# Altium schematic sheet Main.SchDoc
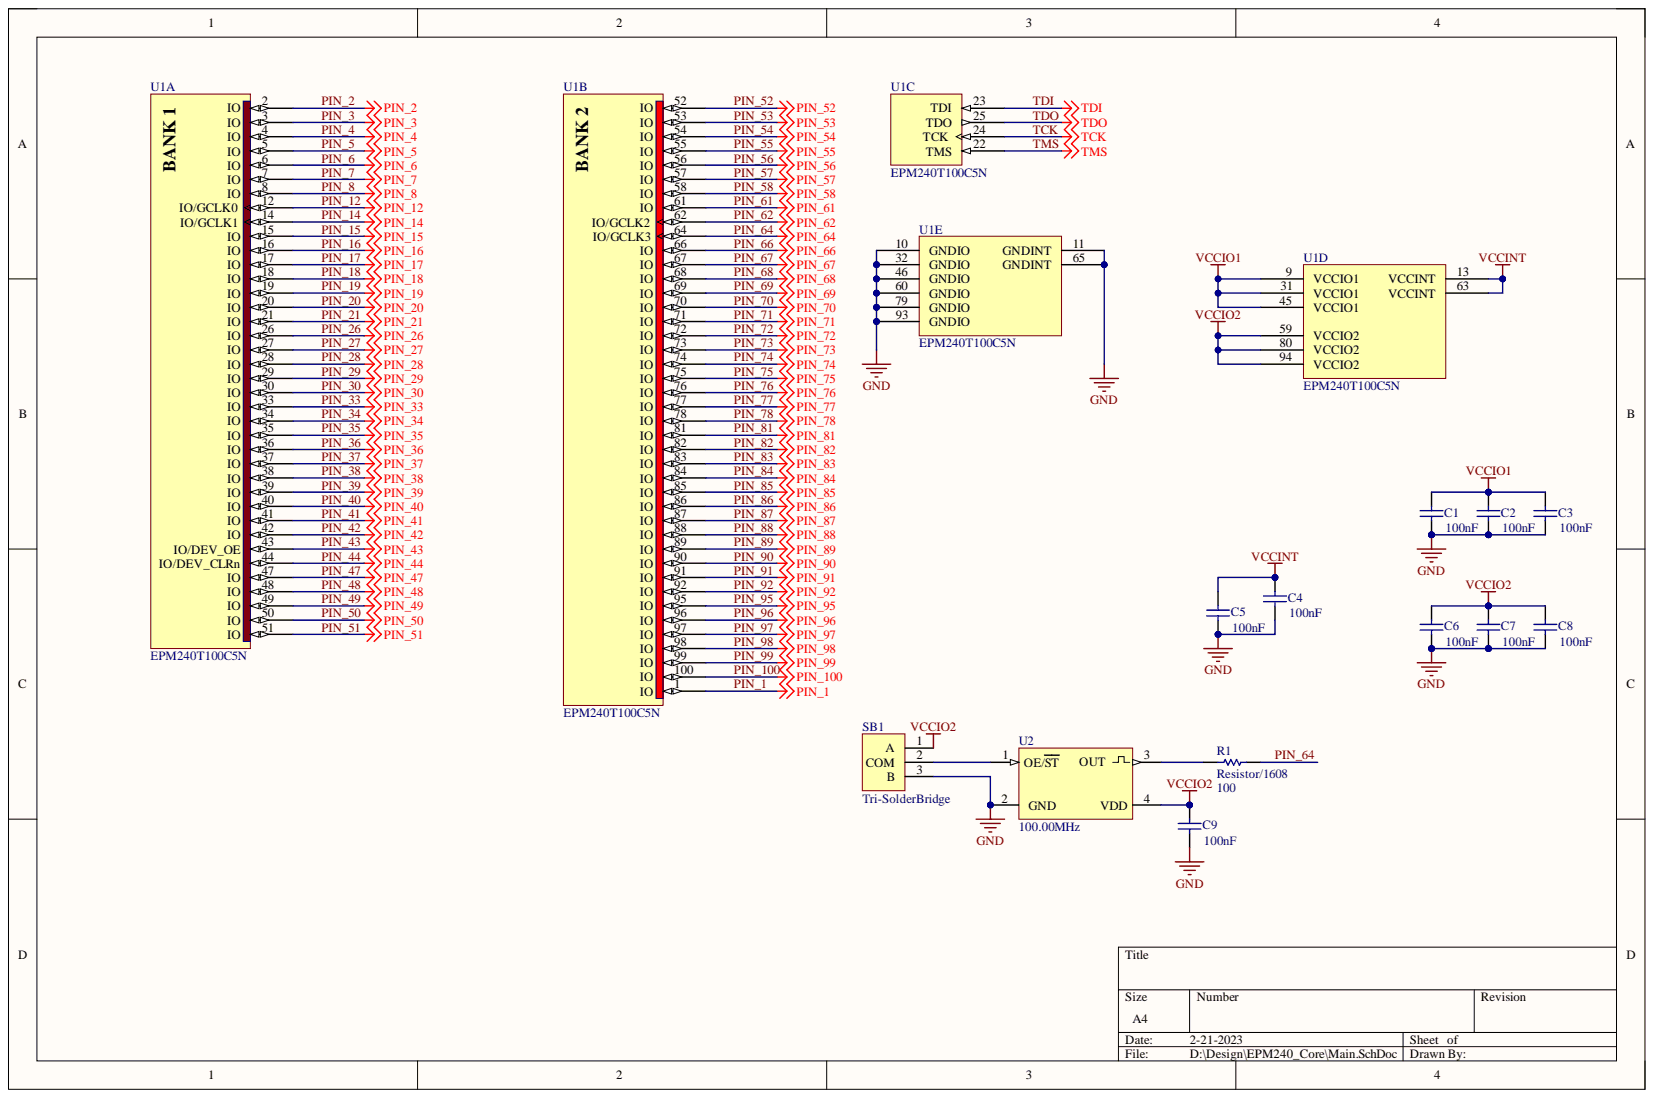
[diagram p0: Main.SchDoc]

## Parts
C1  value 100nF  [Generic 1608 Ceramic]  (hidden comment: Ceramic/1608)
C2  value 100nF  [Generic 1608 Ceramic]  (hidden comment: Ceramic/1608)
C3  value 100nF  [Generic 1608 Ceramic]  (hidden comment: Ceramic/1608)
C4  value 100nF  [Generic 1608 Ceramic]  (hidden comment: Ceramic/1608)
C5  value 100nF  [Generic 1608 Ceramic]  (hidden comment: Ceramic/1608)
C6  value 100nF  [Generic 1608 Ceramic]  (hidden comment: Ceramic/1608)
C7  value 100nF  [Generic 1608 Ceramic]  (hidden comment: Ceramic/1608)
C8  value 100nF  [Generic 1608 Ceramic]  (hidden comment: Ceramic/1608)
C9  value 100nF  [Generic 1608 Ceramic]  (hidden comment: Ceramic/1608)
R1  Resistor/1608  value 100  [Resistor 1608-Footprint]
SB1  Tri-SolderBridge  [Tri-SolderBridge-Footprint-1]
U1  EPM240T100C5N  [TQFP100_L]  (parts A,B,C,D,E)
U2  value 100.00MHz  [SG-8018CB100.000000MHZTJHSA-Footprint-1]  (hidden comment: SG-8018CB100.000000MHZTJHSA)

## Nets
GND: C1.1 C2.1 C3.1 C4.1 C5.1 C6.1 C7.1 C8.1 C9.1 SB1.3 U1.10(GNDIO) U1.11(GNDINT) U1.32(GNDIO) U1.46(GNDIO) U1.60(GNDIO) U1.65(GNDINT) U1.79(GNDIO) U1.93(GNDIO) U2.2(GND)
VCCINT: C4.2 C5.2 U1.13(VCCINT) U1.63(VCCINT)
VCCIO1: C1.2 C2.2 C3.2 U1.9(VCCIO1) U1.31(VCCIO1) U1.45(VCCIO1)
VCCIO2: C6.2 C7.2 C8.2 C9.2 SB1.1 U1.59(VCCIO2) U1.80(VCCIO2) U1.94(VCCIO2) U2.4(VDD)
PIN_1: U1.1(IO)
PIN_2: U1.2(IO)
PIN_3: U1.3(IO)
PIN_4: U1.4(IO)
PIN_5: U1.5(IO)
PIN_6: U1.6(IO)
PIN_7: U1.7(IO)
PIN_8: U1.8(IO)
PIN_12: U1.12(IO/GCLK0)
PIN_14: U1.14(IO/GCLK1)
PIN_15: U1.15(IO)
PIN_16: U1.16(IO)
PIN_17: U1.17(IO)
PIN_18: U1.18(IO)
PIN_19: U1.19(IO)
PIN_20: U1.20(IO)
PIN_21: U1.21(IO)
PIN_26: U1.26(IO)
PIN_27: U1.27(IO)
PIN_28: U1.28(IO)
PIN_29: U1.29(IO)
PIN_30: U1.30(IO)
PIN_33: U1.33(IO)
PIN_34: U1.34(IO)
PIN_35: U1.35(IO)
PIN_36: U1.36(IO)
PIN_37: U1.37(IO)
PIN_38: U1.38(IO)
PIN_39: U1.39(IO)
PIN_40: U1.40(IO)
PIN_41: U1.41(IO)
PIN_42: U1.42(IO)
PIN_43: U1.43(IO/DEV_OE)
PIN_44: U1.44(IO/DEV_CLRn)
PIN_47: U1.47(IO)
PIN_48: U1.48(IO)
PIN_49: U1.49(IO)
PIN_50: U1.50(IO)
PIN_51: U1.51(IO)
PIN_52: U1.52(IO)
PIN_53: U1.53(IO)
PIN_54: U1.54(IO)
PIN_55: U1.55(IO)
PIN_56: U1.56(IO)
PIN_57: U1.57(IO)
PIN_58: U1.58(IO)
PIN_61: U1.61(IO)
PIN_62: U1.62(IO/GCLK2)
PIN_64: R1.2 U1.64(IO/GCLK3)
PIN_66: U1.66(IO)
PIN_67: U1.67(IO)
PIN_68: U1.68(IO)
PIN_69: U1.69(IO)
PIN_70: U1.70(IO)
PIN_71: U1.71(IO)
PIN_72: U1.72(IO)
PIN_73: U1.73(IO)
PIN_74: U1.74(IO)
PIN_75: U1.75(IO)
PIN_76: U1.76(IO)
PIN_77: U1.77(IO)
PIN_78: U1.78(IO)
PIN_81: U1.81(IO)
PIN_82: U1.82(IO)
PIN_83: U1.83(IO)
PIN_84: U1.84(IO)
PIN_85: U1.85(IO)
PIN_86: U1.86(IO)
PIN_87: U1.87(IO)
PIN_88: U1.88(IO)
PIN_89: U1.89(IO)
PIN_90: U1.90(IO)
PIN_91: U1.91(IO)
PIN_92: U1.92(IO)
PIN_95: U1.95(IO)
PIN_96: U1.96(IO)
PIN_97: U1.97(IO)
PIN_98: U1.98(IO)
PIN_99: U1.99(IO)
PIN_100: U1.100(IO)
TCK: U1.24(TCK)
TDI: U1.23(TDI)
TDO: U1.25(TDO)
TMS: U1.22(TMS)
NetR1_1: R1.1 U2.3
NetSB1_2: SB1.2 U2.1(OE/ST)

## Library data of the parts
C1-C9 = CMP-002-0009-3: Generic 1608 Ceramic Capacitor; Capacitor Type: Ceramic; Case/Package: 1608; Component Kind: Standard; Library Name: C6H6`s Vault; Mount: SMD; Pins: 2; RoHS: Yes
R1 = CMP-001-0018-2: Generic 1608 Resistor; Case/Package: Bulk; Component Kind: Standard; Library Name: C6H6`s Vault; Mount: SMD; Pins: 2; RoHS: Yes
SB1 = CMP-003-0018-2: Component Kind: Standard; Description: Tri-SolderBridge; Library Name: C6H6`s Vault
U1 = CMP-00856-1: CPLD MAX® II Family 192 Macro Cells 201.1MHz 0.18um Technology 2.5V/3.3V 100-Pin TQFP Tray; Frequency: 1.8797GHz; Max Supply Voltage: 3.6V; Memory Size: 8192b; Memory Type: FLASH; Min Supply Voltage: 2.375V; Nominal Supply Current: 55mA; Number of I/Os: 80; Number of Logic Blocks (LABs): 24; Number of Macro Cells: 192; Operating Supply Voltage: 3.3V; Propagation Delay: 7.5ns; Speed Grade: 5; Supply Current: 110mA; Case/Package: TQFP; Component Kind: Standard; Height: 1mm; Lead Free: Lead Free; Length: 14mm; Library Name: C6H6`s Vault; Max Frequency: 201.1MHz; Max Operating Temperature: 85°C; Min Operating Temperature: 0°C; Mount: Surface Mount; Number of Inputs: 80; Number of Logic Elements/Cells: 24; Number of Macrocells: 192; Number of Outputs: 80; Number of Pins: 100; Number of Programmable I/O: 80; Operating Supply Current: 55mA; Package Quantity: 90; Packaging: Tray; PartId: 1; Radiation Hardening: No; REACH SVHC: Unknown; RoHS Compliant: Yes; Series: MAX® II; Termination: SMD/SMT; Turn-On Delay Time: 7.5ns; Width: 14mm
U2 = CMP-ROOT-00284-2: Oscillator XO 100MHz ±50ppm 15pF CMOS 55% 1.8V/2.5V/3.3V 4-Pin SMD T/R; Case/Package: 5032; Component Kind: Standard; Library Name: C6H6`s Vault; Mount: SMD; Pins: 4; RoHS: Yes
